# Altium schematic sheet SEVOR_MOTOR.SchDoc(SEVOR_MOTOR)
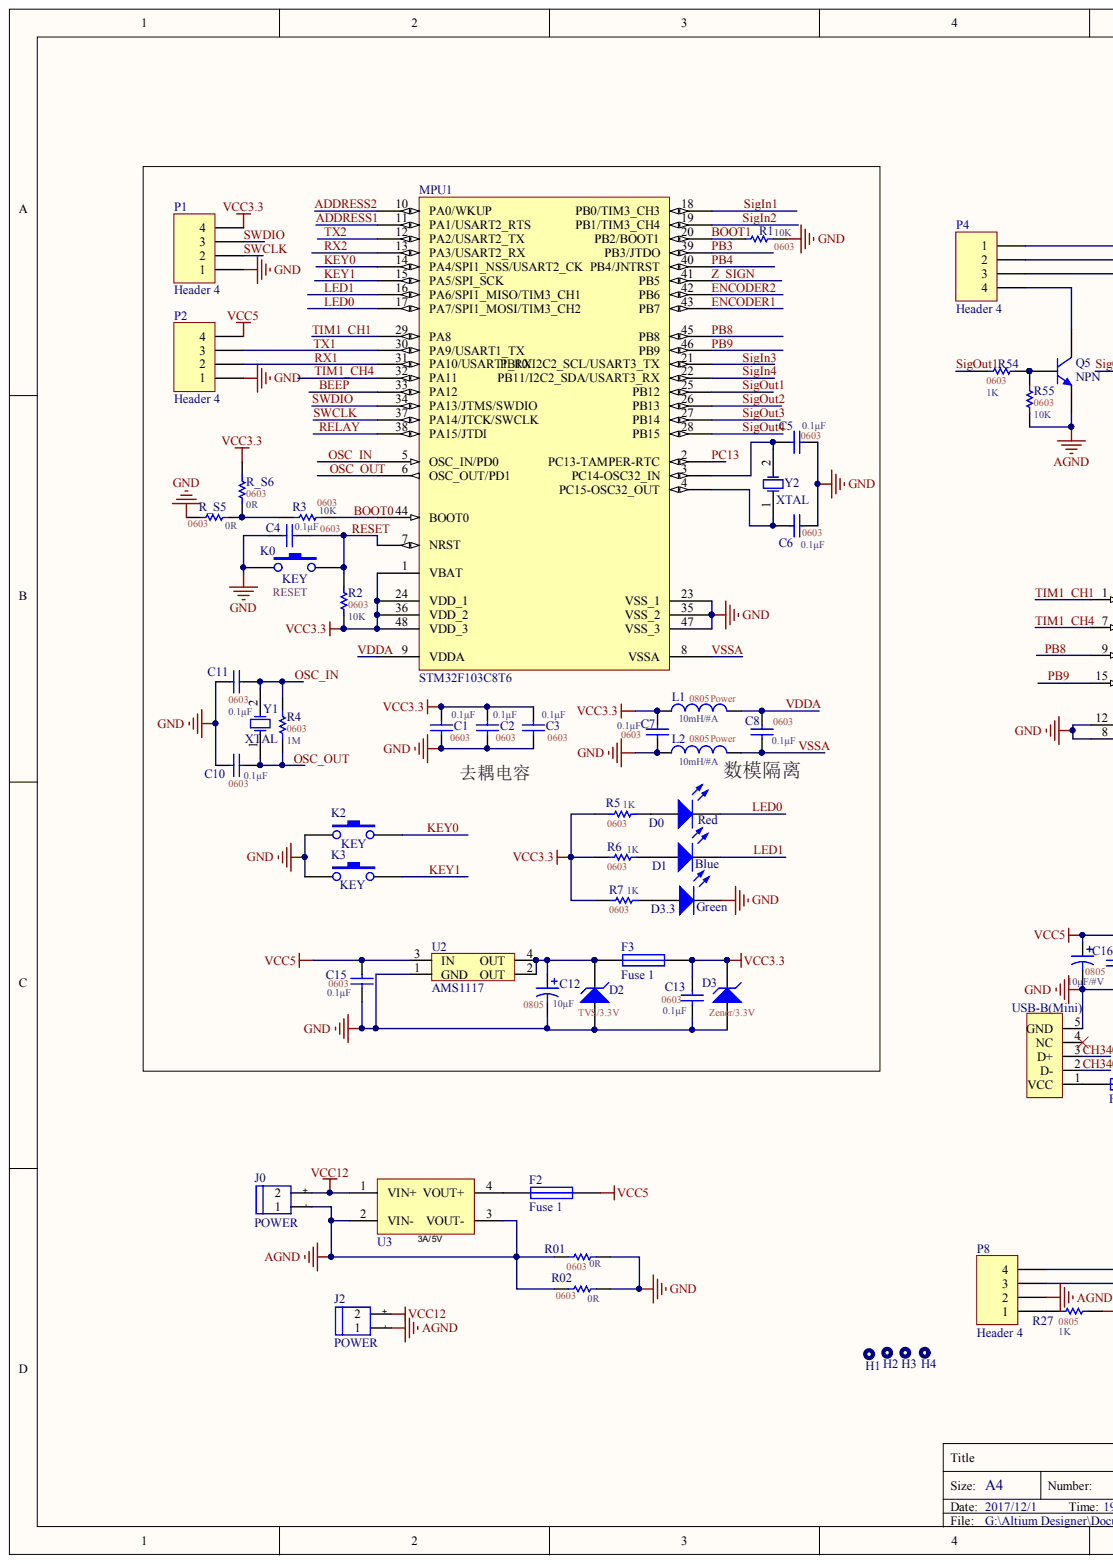
[diagram p0: SEVOR_MOTOR.SchDoc, left]
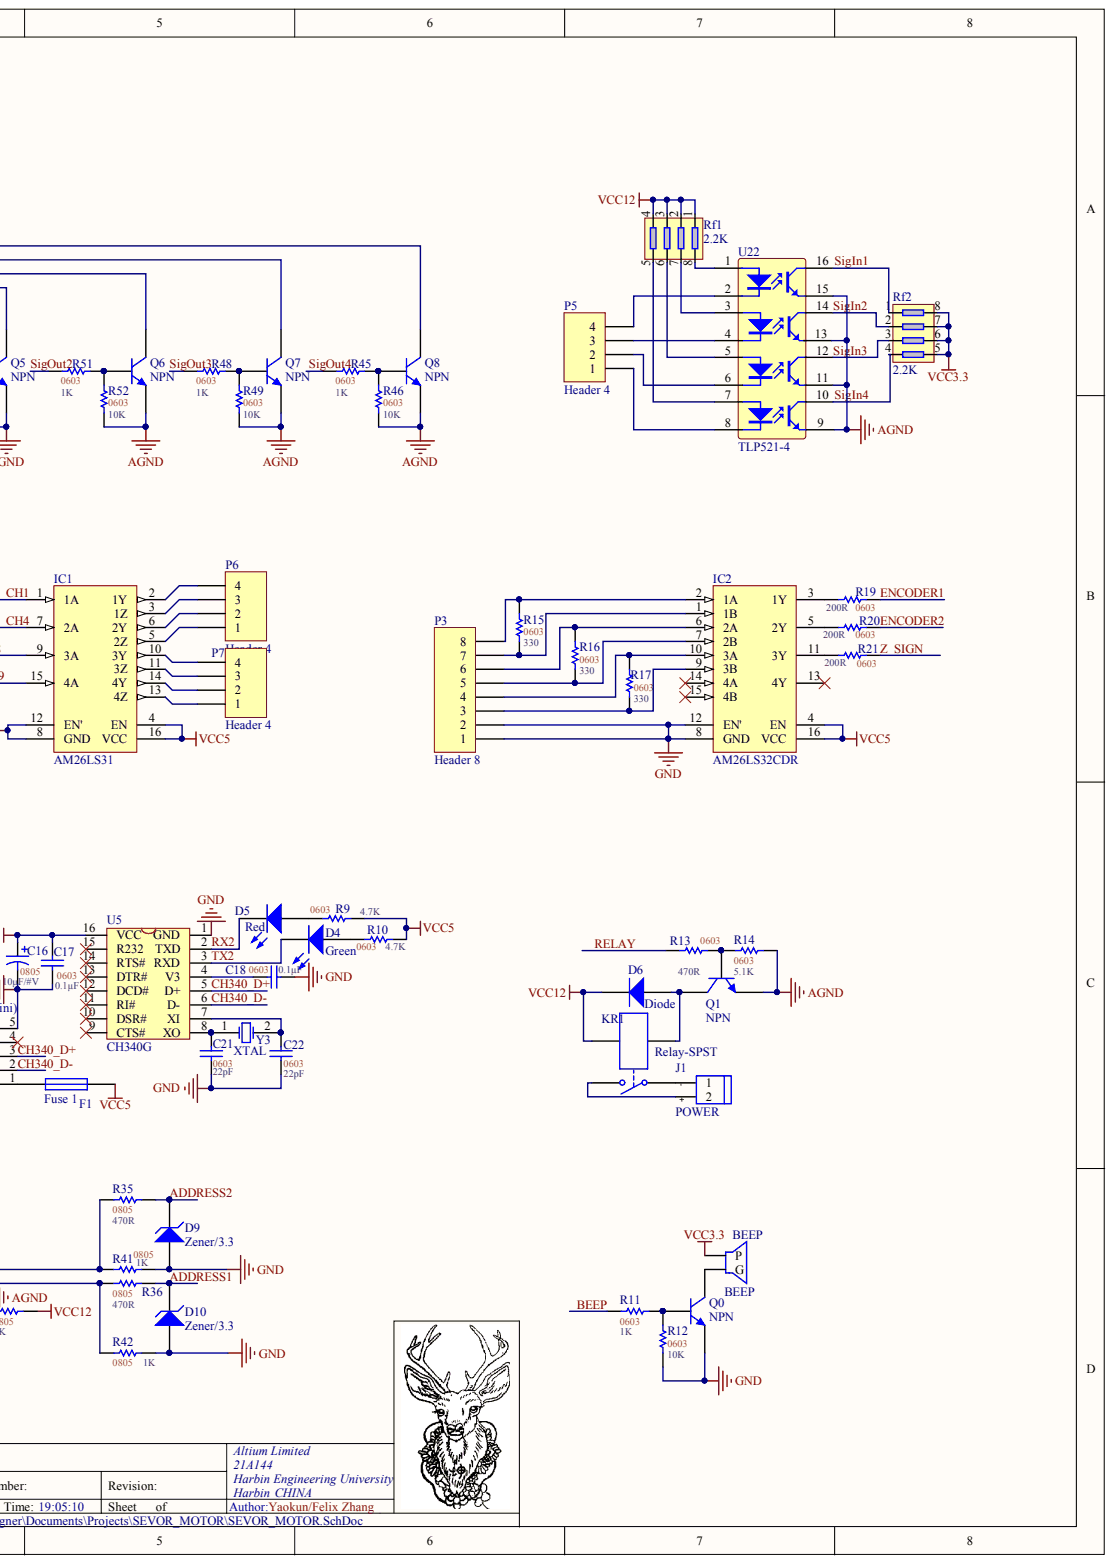
[diagram p1: SEVOR_MOTOR.SchDoc, right]

## Parts
BEEP  BEEP  [BELL-5]
C1  0603  value 0.1μF  [CAP_0603_16*08]
C2  0603  value 0.1μF  [CAP_0603_16*08]
C3  0603  value 0.1μF  [CAP_0603_16*08]
C4  0603  value 0.1μF  [CAP_0603_16*08]
C5  0603  value 0.1μF  [CAP_0603_16*08]
C6  0603  value 0.1μF  [CAP_0603_16*08]
C7  0603  value 0.1μF  [CAP_0603_16*08]
C8  0603  value 0.1μF  [CAP_0603_16*08]
C10  0603  value 0.1μF  [CAP_0603_16*08]
C11  0603  value 0.1μF  [CAP_0603_16*08]
C12  value 10μF  PackageReference: 0805  [CAP_0805_20*12]  (hidden comment: Polar)
C13  0603  value 0.1μF  [CAP_0603_16*08]
C15  0603  value 0.1μF  [CAP_0603_16*08]
C16  value 10μF/#V  PackageReference: 0805  [CAP_0805_20*12]  (hidden comment: Polar)
C17  0603  value 0.1μF  [CAP_0603_16*08]
C18  0603  value 0.1μF  [CAP_0603_16*08]
C21  0603  value 22pF  [CAP_0603_16*08]
C22  0603  value 22pF  [CAP_0603_16*08]
D0  Red  [LED_0805]
D1  Blue  [LED_0805]
D2  TVS/3.3V  [SOD523]
D3  Zener/3.3V  [SOD323]
D3.3  Green  [LED_0805]
D4  Green  [CAP_0603_16*08]
D5  Red  [CAP_0603_16*08]
D6  Diode  [Diode1206]
D9  Zener/3.3  [SOD323]
D10  Zener/3.3  [SOD323]
F1  Fuse 1  [RES_0805_20*12]
F2  Fuse 1  [FUSE3.5*5.5]
F3  Fuse 1  [RES_0805_20*12]
H1  pad  [PAD_M3]  (value not drawn)
H2  pad  [PAD_M3]  (value not drawn)
H3  pad  [PAD_M3]  (value not drawn)
H4  pad  [PAD_M3]  (value not drawn)
IC1  AM26LS31  [SOP16]
IC2  AM26LS32CDR  [SOP16]
J0  POWER  [HADER2_55691]
J1  POWER  [HEAD2L-0.8]
J2  POWER  [Header2_hc5.08]
K0  KEY  [KEY_4]
K2  KEY  [KEY_2]
K3  KEY  [KEY_2]
KR1  Relay-SPST  [Relay_JZC-32F]
L1  value 10mH/#A  [IND_0805_20*12]  (hidden comment: MODEL?)
L2  value 10mH/#A  [IND_0805_20*12]  (hidden comment: MODEL?)
MPU1  STM32F103C8T6  [LQFP48_N]
P1  Header 4  [P4_OUT]
P2  Header 4  [P4_OUT]
P3  Header 8  [P8]
P4  Header 4  [P4]
P5  Header 4  [P4]
P6  Header 4  [P4]
P7  Header 4  [P4]
P8  Header 4  [P4]
Q0  NPN  [SOT23_J3Y]
Q1  NPN  [SOT23_J3Y]
Q5  NPN  [SOT23_J3Y]
Q6  NPN  [SOT23_J3Y]
Q7  NPN  [SOT23_J3Y]
Q8  NPN  [SOT23_J3Y]
R01  value 0R  PackageReference: 0603  [RES_1206]  (hidden comment: SMD)
R1  value 10K  PackageReference: 0603  [RES_0603_16*08]  (hidden comment: SMD)
R02  value 0R  PackageReference: 0603  [RES_1206]  (hidden comment: SMD)
R2  value 10K  PackageReference: 0603  [RES_0603_16*08]  (hidden comment: SMD)
R3  value 10K  PackageReference: 0603  [RES_0603_16*08]  (hidden comment: SMD)
R4  value 1M  PackageReference: 0603  [RES_0805_20*12]  (hidden comment: SMD)
R5  value 1K  PackageReference: 0603  [RES_0603_16*08]  (hidden comment: SMD)
R6  value 1K  PackageReference: 0603  [RES_0603_16*08]  (hidden comment: SMD)
R7  value 1K  PackageReference: 0603  [RES_0603_16*08]  (hidden comment: SMD)
R9  value 4.7K  PackageReference: 0603  [RES_0603_16*08]  (hidden comment: SMD)
R10  value 4.7K  PackageReference: 0603  [RES_0603_16*08]  (hidden comment: SMD)
R11  value 1K  PackageReference: 0603  [RES_0603_16*08]  (hidden comment: SMD)
R12  value 10K  PackageReference: 0603  [RES_0603_16*08]  (hidden comment: SMD)
R13  value 470R  PackageReference: 0603  [RES_0603_16*08]  (hidden comment: SMD)
R14  value 5.1K  PackageReference: 0603  [RES_0603_16*08]  (hidden comment: SMD)
R15  value 330  PackageReference: 0603  [RES_0603_16*08]  (hidden comment: SMD)
R16  value 330  PackageReference: 0603  [RES_0603_16*08]  (hidden comment: SMD)
R17  value 330  PackageReference: 0603  [RES_0603_16*08]  (hidden comment: SMD)
R19  value 200R  PackageReference: 0603  [RES_0603_16*08]  (hidden comment: SMD)
R20  value 200R  PackageReference: 0603  [RES_0603_16*08]  (hidden comment: SMD)
R21  value 200R  PackageReference: 0603  [RES_0603_16*08]  (hidden comment: SMD)
R27  value 1K  PackageReference: 0805  [RES_0805_20*12]  (hidden comment: SMD)
R35  value 470R  PackageReference: 0805  [RES_0805_20*12]  (hidden comment: SMD)
R36  value 470R  PackageReference: 0805  [RES_0805_20*12]  (hidden comment: SMD)
R41  value 1K  PackageReference: 0805  [RES_0805_20*12]  (hidden comment: SMD)
R42  value 1K  PackageReference: 0805  [RES_0805_20*12]  (hidden comment: SMD)
R45  value 1K  PackageReference: 0603  [RES_0603_16*08]  (hidden comment: SMD)
R46  value 10K  PackageReference: 0603  [RES_0603_16*08]  (hidden comment: SMD)
R48  value 1K  PackageReference: 0603  [RES_0603_16*08]  (hidden comment: SMD)
R49  value 10K  PackageReference: 0603  [RES_0603_16*08]  (hidden comment: SMD)
R51  value 1K  PackageReference: 0603  [RES_0603_16*08]  (hidden comment: SMD)
R52  value 10K  PackageReference: 0603  [RES_0603_16*08]  (hidden comment: SMD)
R54  value 1K  PackageReference: 0603  [RES_0603_16*08]  (hidden comment: SMD)
R55  value 10K  PackageReference: 0603  [RES_0603_16*08]  (hidden comment: SMD)
R_S5  value 0R  PackageReference: 0603  [RES_0603_16*08]  (hidden comment: SMD)
R_S6  value 0R  PackageReference: 0603  [RES_0603_16*08]  (hidden comment: SMD)
Rf1  2.2K  [RES_FOUR_0603]
Rf2  2.2K  [RES_FOUR_0603]
U2  AMS1117  [SOT223_M]
U3  Voltage_Trans_Moduel  [3A_Moduel]  (value not drawn)
U5  CH340G  [SOP16]
U22  TLP521-4  [TLP521-4]
USB_SLAVE1  USB-B(Mini)  [USB/SM0.8-6H5]
Y1  XTAL  [XTAL_US]
Y2  XTAL  [XTAL32]
Y3  XTAL  [XTAL_US]

## Nets
AGND: J0.1 J2.1 P8.2 Q1.3 Q5.3 Q6.3 Q7.3 Q8.3 R01.1 R02.1 R14.2 R46.1 R49.1 R52.1 R55.1 U3.2(VIN-) U3.3(VOUT-) U22.9 U22.11 U22.13 U22.15
GND: C1.2 C2.2 C3.2 C4.1 C5.2 C6.2 C7.1 C10.2 C11.2 C12.2 C13.1 C15.1 C16.2 C17.1 C18.2 C21.1 C22.1 D2.1 D3.1 D3.3.2 D9.1 D10.1 IC1.8(GND) IC1.12(EN') IC2.8(GND) IC2.12(EN') K0.1 K2.1 K3.1 L2.1 MPU1.23(VSS_1) MPU1.35(VSS_2) MPU1.47(VSS_3) P1.1 P2.1 P3.1 P3.2 Q0.3 R01.2 R1.2 R02.2 R12.1 R41.1 R42.1 R_S5.1 U2.1(GND) U5.1(GND) USB_SLAVE1.5(GND)
VCC3.3: BEEP.1 C1.1 C2.1 C3.1 C7.2 C13.2 D3.2 F3.2 L1.1 MPU1.1(VBAT) MPU1.24(VDD_1) MPU1.36(VDD_2) MPU1.48(VDD_3) P1.4 R2.1 R5.1 R6.1 R7.1 R_S6.1 Rf2.5 Rf2.6 Rf2.7 Rf2.8
VCC5: C15.2 C16.1 C17.2 F1.2 F2.2 IC1.4(EN) IC1.16(VCC) IC2.4(EN) IC2.16(VCC) P2.4 R9.2 R10.1 U2.3(IN) U5.16(VCC)
VCC12: D6.2 J0.2 J2.2 KR1.2 R27.1 Rf1.1 Rf1.2 Rf1.3 Rf1.4 U3.1(VIN+)
ADDRESS1: D10.2 MPU1.11(PA1/USART2_RTS) R36.1
ADDRESS2: D9.2 MPU1.10(PA0/WKUP) R35.1
BEEP: MPU1.33(PA12) R11.1
BOOT0: MPU1.44(BOOT0) R3.2
BOOT1: MPU1.20(PB2/BOOT1) R1.1
CH340_D+: U5.5(D+) USB_SLAVE1.3(D+)
CH340_D-: U5.6(D-) USB_SLAVE1.2(D-)
ENCODER1: MPU1.43(PB7) R19.2
ENCODER2: MPU1.42(PB6) R20.2
KEY0: K2.2 MPU1.14(PA4/SPI1_NSS/USART2_CK)
KEY1: K3.2 MPU1.15(PA5/SPI_SCK)
LED0: D0.2 MPU1.17(PA7/SPI1_MOSI/TIM3_CH2)
LED1: D1.2 MPU1.16(PA6/SPI1_MISO/TIM3_CH1)
OSC_IN: C11.1 MPU1.5(OSC_IN/PD0) R4.2 Y1.2
OSC_OUT: C10.1 MPU1.6(OSC_OUT/PD1) R4.1 Y1.1
PB3: MPU1.39(PB3/JTDO)
PB4: MPU1.40(PB4/JNTRST)
PB8: IC1.9(3A) MPU1.45(PB8)
PB9: IC1.15(4A) MPU1.46(PB9)
PC13: MPU1.2(PC13-TAMPER-RTC)
RELAY: MPU1.38(PA15/JTDI) R13.1
RESET: C4.2 K0.2 MPU1.7(NRST) R2.2
RX1: MPU1.31(PA10/USART1_RX) P2.2
RX2: D5.2 MPU1.13(PA3/USART2_RX) U5.2(TXD)
SIGIN1: MPU1.18(PB0/TIM3_CH3) Rf2.1 U22.16
SIGIN2: MPU1.19(PB1/TIM3_CH4) Rf2.2 U22.14
SIGIN3: MPU1.21(PB10/I2C2_SCL/USART3_TX) Rf2.3 U22.12
SIGIN4: MPU1.22(PB11/I2C2_SDA/USART3_RX) Rf2.4 U22.10
SIGOUT1: MPU1.25(PB12) R54.1
SIGOUT2: MPU1.26(PB13) R51.1
SIGOUT3: MPU1.27(PB14) R48.1
SIGOUT4: MPU1.28(PB15) R45.1
SWCLK: MPU1.37(PA14/JTCK/SWCLK) P1.2
SWDIO: MPU1.34(PA13/JTMS/SWDIO) P1.3
TIM1_CH1: IC1.1(1A) MPU1.29(PA8)
TIM1_CH4: IC1.7(2A) MPU1.32(PA11)
TX1: MPU1.30(PA9/USART1_TX) P2.3
TX2: D4.2 MPU1.12(PA2/USART2_TX) U5.3(RXD)
VDDA: C8.2 L1.2 MPU1.9(VDDA)
VSSA: C8.1 L2.2 MPU1.8(VSSA)
Z_SIGN: MPU1.41(PB5) R21.2
NetBEEP_2: BEEP.2 Q0.1
NetC5_1: C5.1 MPU1.3(PC14-OSC32_IN) Y2.2
NetC6_1: C6.1 MPU1.4(PC15-OSC32_OUT) Y2.1
NetC12_1: C12.1 D2.2 F3.1 U2.2(OUT) U2.4(OUT)
NetC18_1: C18.1 U5.4(V3)
NetC21_2: C21.2 U5.8(XO) Y3.1
NetC22_2: C22.2 U5.7(XI) Y3.2
NetD0_1: D0.1 R5.2
NetD1_1: D1.1 R6.2
NetD3.3_1: D3.3.1 R7.2
NetD4_1: D4.1 R10.2
NetD5_1: D5.1 R9.1
NetD6_1: D6.1 KR1.1 Q1.1
NetF1_1: F1.1 USB_SLAVE1.1(VCC)
NetF2_1: F2.1 U3.4(VOUT+)
NetIC1_2: IC1.2(1Y) P6.4
NetIC1_3: IC1.3(1Z) P6.3
NetIC1_5: IC1.5(2Z) P6.1
NetIC1_6: IC1.6(2Y) P6.2
NetIC1_10: IC1.10(3Y) P7.4
NetIC1_11: IC1.11(3Z) P7.3
NetIC1_13: IC1.13(4Z) P7.1
NetIC1_14: IC1.14(4Y) P7.2
NetIC2_1: IC2.1(1B) P3.7 R15.2
NetIC2_2: IC2.2(1A) P3.8 R15.1
NetIC2_3: IC2.3(1Y) R19.1
NetIC2_5: IC2.5(2Y) R20.1
NetIC2_6: IC2.6(2A) P3.6 R16.1
NetIC2_7: IC2.7(2B) P3.5 R16.2
NetIC2_9: IC2.9(3B) P3.3 R17.2
NetIC2_10: IC2.10(3A) P3.4 R17.1
NetIC2_11: IC2.11(3Y) R21.1
NetJ1_1: J1.1 KR1.3
NetJ1_2: J1.2 KR1.4
NetP4_1: P4.1 Q8.1
NetP4_2: P4.2 Q7.1
NetP4_3: P4.3 Q6.1
NetP4_4: P4.4 Q5.1
NetP5_1: P5.1 U22.8
NetP5_2: P5.2 U22.6
NetP5_3: P5.3 U22.4
NetP5_4: P5.4 U22.2
NetP8_1: P8.1 R27.2
NetP8_3: P8.3 R36.2 R42.2
NetP8_4: P8.4 R35.2 R41.2
NetQ0_2: Q0.2 R11.2 R12.2
NetQ1_2: Q1.2 R13.2 R14.1
NetQ5_2: Q5.2 R54.2 R55.2
NetQ6_2: Q6.2 R51.2 R52.2
NetQ7_2: Q7.2 R48.2 R49.2
NetQ8_2: Q8.2 R45.2 R46.2
NetR3_1: R3.1 R_S5.2 R_S6.2
NetRf1_5: Rf1.5 U22.7
NetRf1_6: Rf1.6 U22.5
NetRf1_7: Rf1.7 U22.3
NetRf1_8: Rf1.8 U22.1

Not connected: IC2 13(4Y) 14(4A) 15(4B); U5 9(CTS#) 10(DSR#) 11(RI#) 12(DCD#) 13(DTR#) 14(RTS#) 15(R232); USB_SLAVE1 4(NC)

## Library data of the parts
C1-C8 C10 C13 C15 C17 C18 C21 C22 = Cap: Capacitor; Component Kind: Standard; LatestRevisionDate: 17-Jul-2002; LatestRevisionNote: Re-released for DXP Platform.; PackageDescription: 0603; PackageReference: 0603; Published: 8-Jun-2000; Library Name: Belong_to_Kongkong.SchLib; Publisher: Altium Limited
C11 = Cap: Capacitor; Value: 0.1μF; Component Kind: Standard; LatestRevisionDate: 17-Jul-2002; LatestRevisionNote: Re-released for DXP Platform.; PackageDescription: 0603; PackageReference: 0603; Published: 8-Jun-2000; Library Name: Belong_to_Kongkong.SchLib; Publisher: Altium Limited
C12 C16 = Cap Pol: Polarized Capacitor (Axial); Component Kind: Standard; LatestRevisionDate: 17-Jul-2002; LatestRevisionNote: Re-released for DXP Platform.; PackageDescription: Polar; Published: 1206; Library Name: Belong_to_Kongkong.SchLib; Publisher: Altium Limited
D0 D1 D3.3 D4 D5 = LED: Typical RED, GREEN, YELLOW, AMBER GaAs LED; Component Kind: Standard; LatestRevisionDate: 17-Jul-2002; LatestRevisionNote: Re-released for DXP Platform.; PackageDescription: SM LED; 2 Flat Leads; PackageReference: 3.2X1.6X1.1; PackageVersion: Feb-2002; Published: 8-Jun-2000; Library Name: Belong_to_Kongkong.SchLib; Publisher: Altium Limited
D2 D3 D9 D10 = D Zener: Zener Diode; Component Kind: Standard; LatestRevisionDate: 17-Jul-2002; LatestRevisionNote: Re-released for DXP Platform.; PackageDescription: Diode, Thru-Hole; 2 Leads; 0.7 in Pin Spacing; PackageReference: DIODE-0.7; Published: 8-Jun-2000; Library Name: Belong_to_Kongkong.SchLib; Publisher: Altium Limited
D6 = Diode: Default Diode; Code_JEDEC: DO-214-AB; Component Kind: Standard; LatestRevisionDate: 17-Jul-2002; LatestRevisionNote: Re-released for DXP Platform.; PackageDescription: DO-214-AB/SMC; 2 C-Bend Leads; Body 7.9 x 5.9 mm, inc. leads (LxW); PackageReference: SMC; PackageVersion: Sep-1996; Published: 8-Jun-2000; Library Name: Belong_to_Kongkong.SchLib; Publisher: Altium Limited
F1-F3 = Fuse 1: Fuse; Component Kind: Standard; LatestRevisionDate: 17-Jul-2002; LatestRevisionNote: Re-released for DXP Platform.; PackageDescription: Thru-Hole; 2 Leads; PackageReference: PIN-W2/E2.8; Published: 8-Jun-2000; Library Name: Belong_to_Kongkong.SchLib; Publisher: Altium Limited
IC1 = AM26LS31CDR: 差分输出; Component Kind: Standard; Library Name: Belong_to_Kongkong.SchLib
IC2 = AM26LS32CDR: 差分输入; Component Kind: Standard; Library Name: Belong_to_Kongkong.SchLib
J0-J2 = HEAD2: Component Kind: Standard; Library Name: Belong_to_Kongkong.SchLib
KR1 = Relay-SPST: Single-Pole Single-Throw Relay; Component Kind: Standard; LatestRevisionDate: 17-Jul-2002; LatestRevisionNote: Re-released for DXP Platform.; PackageReference: MODULE4; Published: 24-Mar-1999; Library Name: Belong_to_Kongkong.SchLib; Publisher: Altium Limited
L1 L2 = Inductor: Code_IEC: 1005; Code_JEITA: 0402; Component Kind: Standard; Description: Inductor; LatestRevisionDate: 29-May-2009; LatestRevisionNote: IPC-7351 Footprint Added.; PackageDescription: Power; PackageReference: 0805; Published: 8-Jun-2000; Library Name: Belong_to_Kongkong.SchLib; Publisher: Altium Limited
MPU1 = STM32F103CBT6: STM32 ARM-based 32-bit MCU with 128 Kbytes Flash, 48-pin LQFP, Industrial Temperature; Component Kind: Standard; ComponentLink1Description: Manufacturer Link; ComponentLink1URL: www.st.com/stm32; ComponentLink2Description: Datasheet; ComponentLink2URL: http://www.st.com/stonline/products/literature/ds/13587.pdf; DatasheetVersion: rev6, Mar-2008; Library Name: ST Microcontroller 32-Bit STM32.IntLib; PackageDescription: 48-Pin Low-Profile Quad Flat Pack, 7 x 7 mm Body, 0.5 mm Pitch (LQFP48); PackageReference: LQFP48; PackageVersion: Mar-2008; Published: 30-May-2008; Publisher: Altium Limited
P1 P2 P4-P8 = Header 4: Header, 4-Pin; Component Kind: Standard; LatestRevisionDate: 17-Jul-2002; LatestRevisionNote: Re-released for DXP Platform.; Library Name: Belong_to_Kongkong.SchLib; Publisher: Altium Limited
P3 = Header 8: Header, 8-Pin; Component Kind: Standard; LatestRevisionDate: 17-Jul-2002; LatestRevisionNote: Re-released for DXP Platform.; Library Name: Belong_to_Kongkong.SchLib; Publisher: Altium Limited
Q0 Q1 Q5-Q8 = NPN: NPN Bipolar Transistor; Code_JEDEC: TO-226-AA; Component Kind: Standard; LatestRevisionDate: 17-Jul-2002; LatestRevisionNote: Re-released for DXP Platform.; PackageDescription: TO, Flat Index; 3 In-Line, Axial Leads; Body Dia. 4.8mm; Leads 0.55 x 0.51 mm (max); PackageReference: TO-226-AA; Published: 8-Jun-2000; Library Name: Belong_to_Kongkong.SchLib; Publisher: Altium Limited
R01 R1 R02 R2-R7 R9-R12 R15-R17 R19-R21 R27 R35 R36 R41 R42 R45 R46 R48 R49 R51 R52 R54 R55 R_S5 R_S6 = RES_SMD: Resistor; Component Kind: Standard; LatestRevisionDate: 17-Jul-2002; LatestRevisionNote: Re-released for DXP Platform.; PackageDescription: SMD; Published: 8-Jun-2000; Library Name: Belong_to_Kongkong.SchLib; Publisher: Altium Limited
R13 = RES_SMD: Resistor; Value: 470R; Component Kind: Standard; LatestRevisionDate: 17-Jul-2002; LatestRevisionNote: Re-released for DXP Platform.; PackageDescription: SMD; Published: 8-Jun-2000; Library Name: Belong_to_Kongkong.SchLib; Publisher: Altium Limited
R14 = RES_SMD: Resistor; Value: 5.1K; Component Kind: Standard; LatestRevisionDate: 17-Jul-2002; LatestRevisionNote: Re-released for DXP Platform.; PackageDescription: SMD; Published: 8-Jun-2000; Library Name: Belong_to_Kongkong.SchLib; Publisher: Altium Limited
Rf1 Rf2 = RES_4P: Component Kind: Standard; Library Name: Belong_to_Kongkong.SchLib
U5 = CH340G: USB2UART; Component Kind: Standard; Library Name: Belong_to_Kongkong.SchLib
USB_SLAVE1 = USB5: Component Kind: Standard; Library Name: Belong_to_Kongkong.SchLib
Y1-Y3 = XTAL: Crystal Oscillator; Component Kind: Standard; LatestRevisionDate: 17-Jul-2002; LatestRevisionNote: Re-released for DXP Platform.; PackageDescription: Crystal, Thru-Hole; 2 Leads; Body 3.1 x 8.2 mm (Dia.xH); PackageReference: R38; Published: 8-Jun-2000; Library Name: Belong_to_Kongkong.SchLib; Publisher: Altium Limited
BEEP = BEEP: Component Kind: Standard; Library Name: Belong_to_Kongkong.SchLib
H1-H4 = pad: Component Kind: Standard; Library Name: Belong_to_Kongkong.SchLib
K0 K2 K3 = KEY: : RESET; Component Kind: Standard; Library Name: Belong_to_Kongkong.SchLib
U2 = AMS1117: Component Kind: Standard; Library Name: Belong_to_Kongkong.SchLib
U3 = Voltage_Trans_Moduel: Component Kind: Standard; Library Name: Belong_to_Kongkong.SchLib
U22 = TLP521-4: Component Kind: Standard; Library Name: Belong_to_Kongkong.SchLib
